# Altium schematic sheet Main.SchDoc
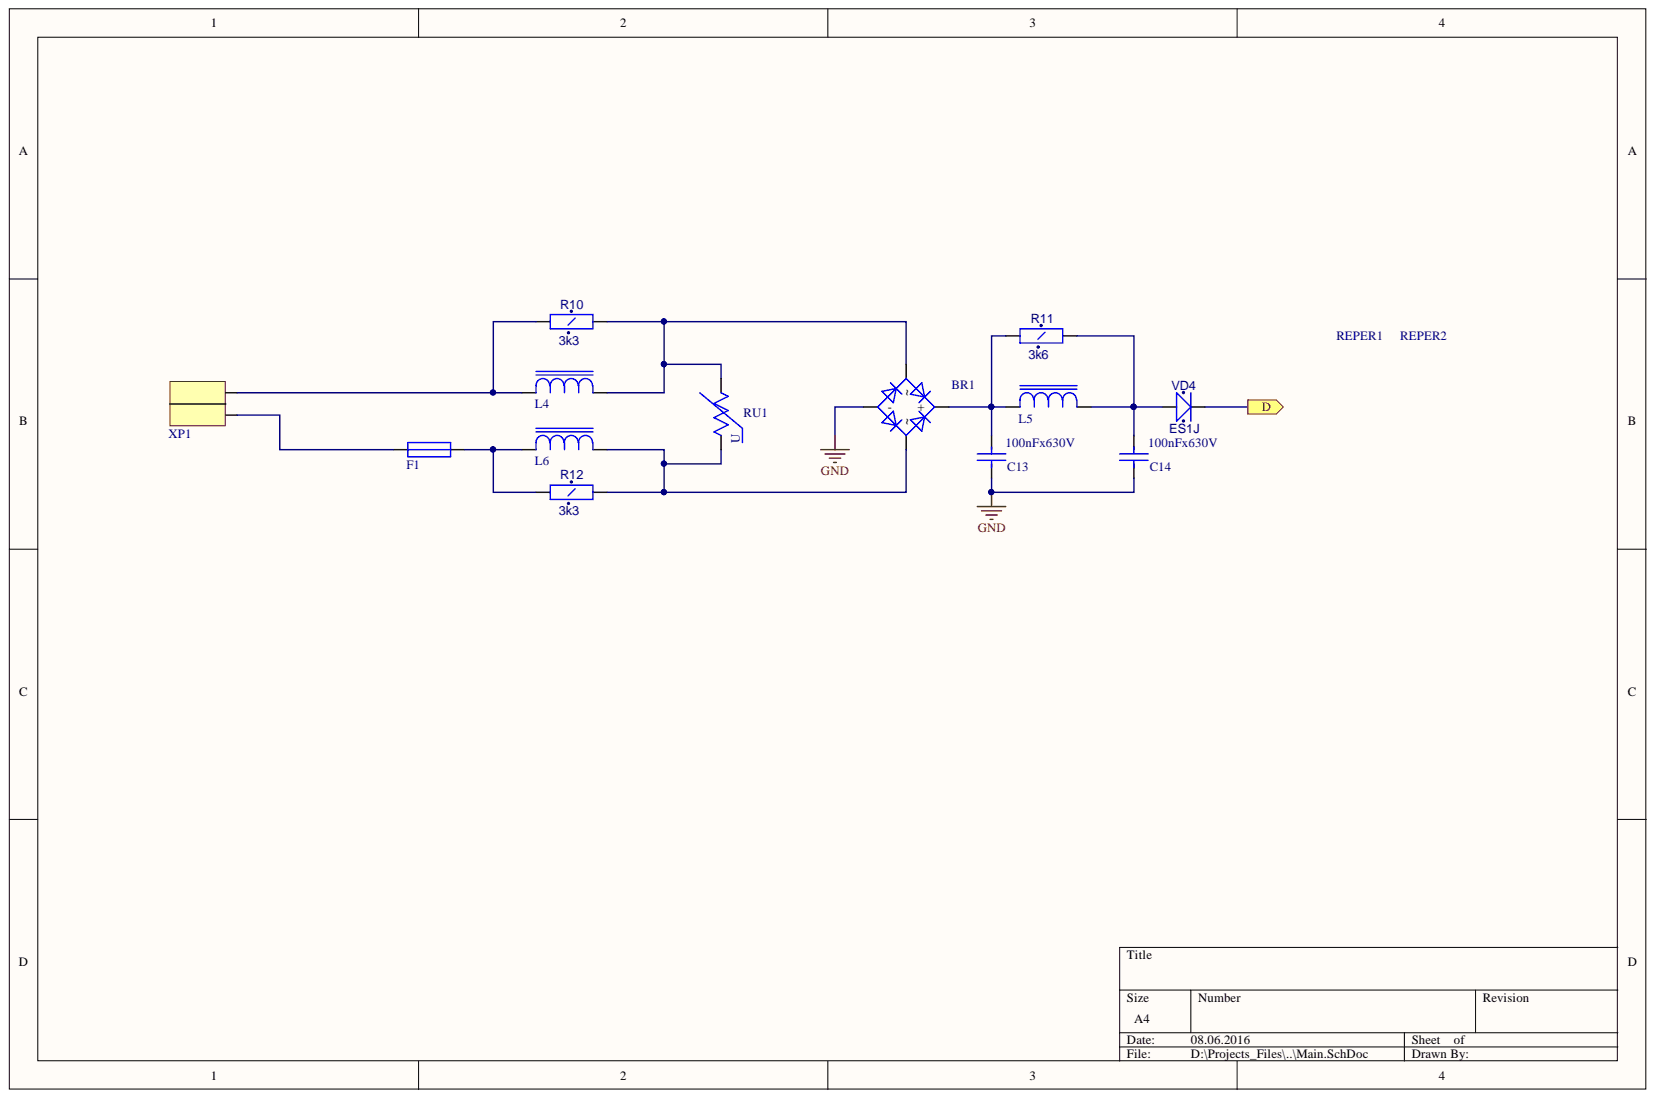
[diagram p0: Main.SchDoc]

## Parts
BR1  DF10S  [SDIP]  (value not drawn)
C13  100nFx630V  [CAP_630V_SMD]
C14  100nFx630V  [CAP_630V_SMD]
F1  (no value)  [Fuse Belfuse]
L4  CDRH129HF-102MC  [IND_CDRH129]  (value not drawn)
L5  CDRH129HF-102MC  [IND_CDRH129]  (value not drawn)
L6  CDRH129HF-102MC  [IND_CDRH129]  (value not drawn)
R10  3k3  [RC1206]
R11  3k6  [RC1206]
R12  3k3  [RC1206]
REPER1  REPER1.2  [REPER1.2]  (value not drawn)
REPER2  REPER1.2  [REPER1.2]  (value not drawn)
RU1  KLS6-07D  [Varistor_7mm_SMD]  (value not drawn)
VD4  ES1J  [SMA]
XP1  (no value)  [Poke-In 2pin]

## Ports
D

## Nets
GND: BR1.4(-) C13.1 C14.1
D: VD4.2 ; port D
NetBR1_1: BR1.1(~) L4.2 R10.2 RU1.2
NetBR1_2: BR1.2(~) L6.2 R12.2 RU1.1
NetBR1_3: BR1.3(+) C13.2 L5.1 R11.1
NetC14_2: C14.2 L5.2 R11.2 VD4.1
NetF1_1: F1.1 XP1.4
NetF1_2: F1.2 L6.1 R12.1
NetL4_1: L4.1 R10.1 XP1.3

## Library data of the parts
C13 C14 = Cap_HV: Component Kind: Standard; Value: 100nFx630V; Library Name: gprs_modem_2.SchLib
F1 = Fuse Belufse: Component Kind: Standard; Library Name: gprs_modem_2.SchLib
L4-L6 = CDRH129: Component Kind: Standard; Value: CDRH129HF-102MC; Library Name: gprs_modem_2.SchLib
R10-R12 = RC1206: Component Kind: Standard; Description: RC1206; Library Name: gprs_modem_2.SchLib
  R10 R12: Value: 3k3
  R11: Value: 3k6
VD4 = SS14: Schottky Rectifier; ComponentField6: Published 8-Jun-2000, Modified 10-July-2000: SIM Model Added.; OriginalName: 10BQ100; Component Kind: Standard; Simulation: 10BQ100; Value: ES1J; Library Name: gprs_modem_2.SchLib
XP1 = Poke-IN 2Pin: Component Kind: Standard; Library Name: gprs_modem_2.SchLib
BR1 = DF10S: Component Kind: Standard; Value: DF10S; Library Name: gprs_modem_2.SchLib
REPER1 REPER2 = REPER1.2: Component Kind: Standard; Description: REPER1.2; Library Name: gprs_modem.SchLib
RU1 = KLS6-07D: Component Kind: Standard; Value: KLS6-07D; Library Name: gprs_modem_2.SchLib
